# Altium schematic sheet 04 - FT2232_USB_UART_JTAG.SchDoc("04 - FT2232_USB_UART_JTAG")
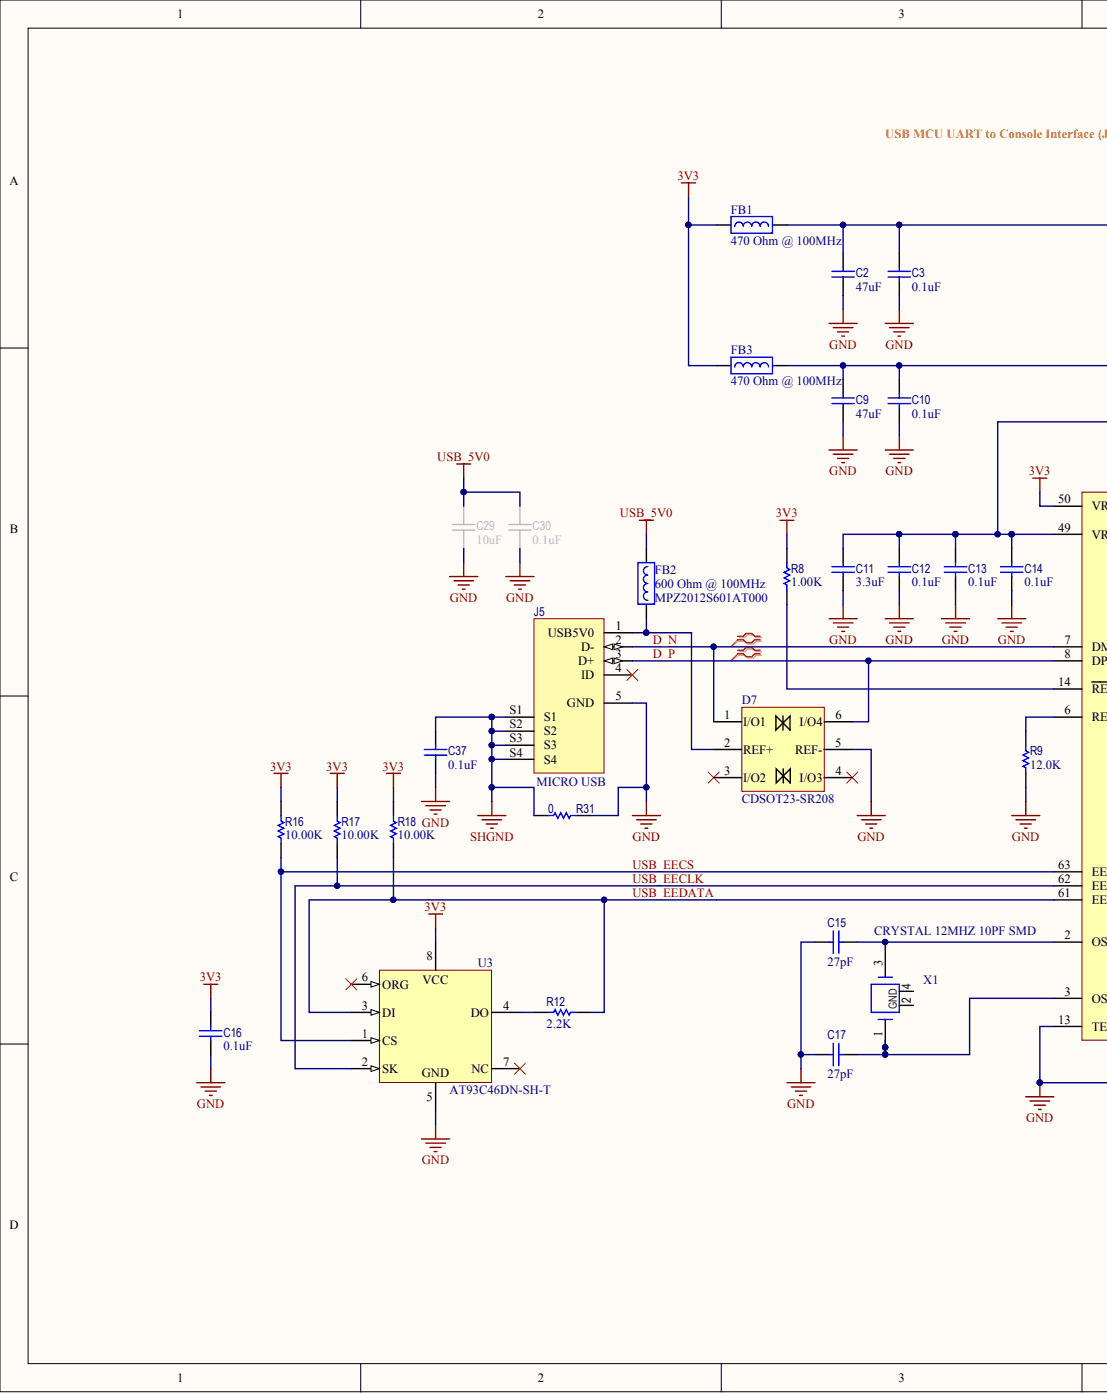
[diagram p0: 04 - FT2232_USB_UART_JTAG.SchDoc, left]
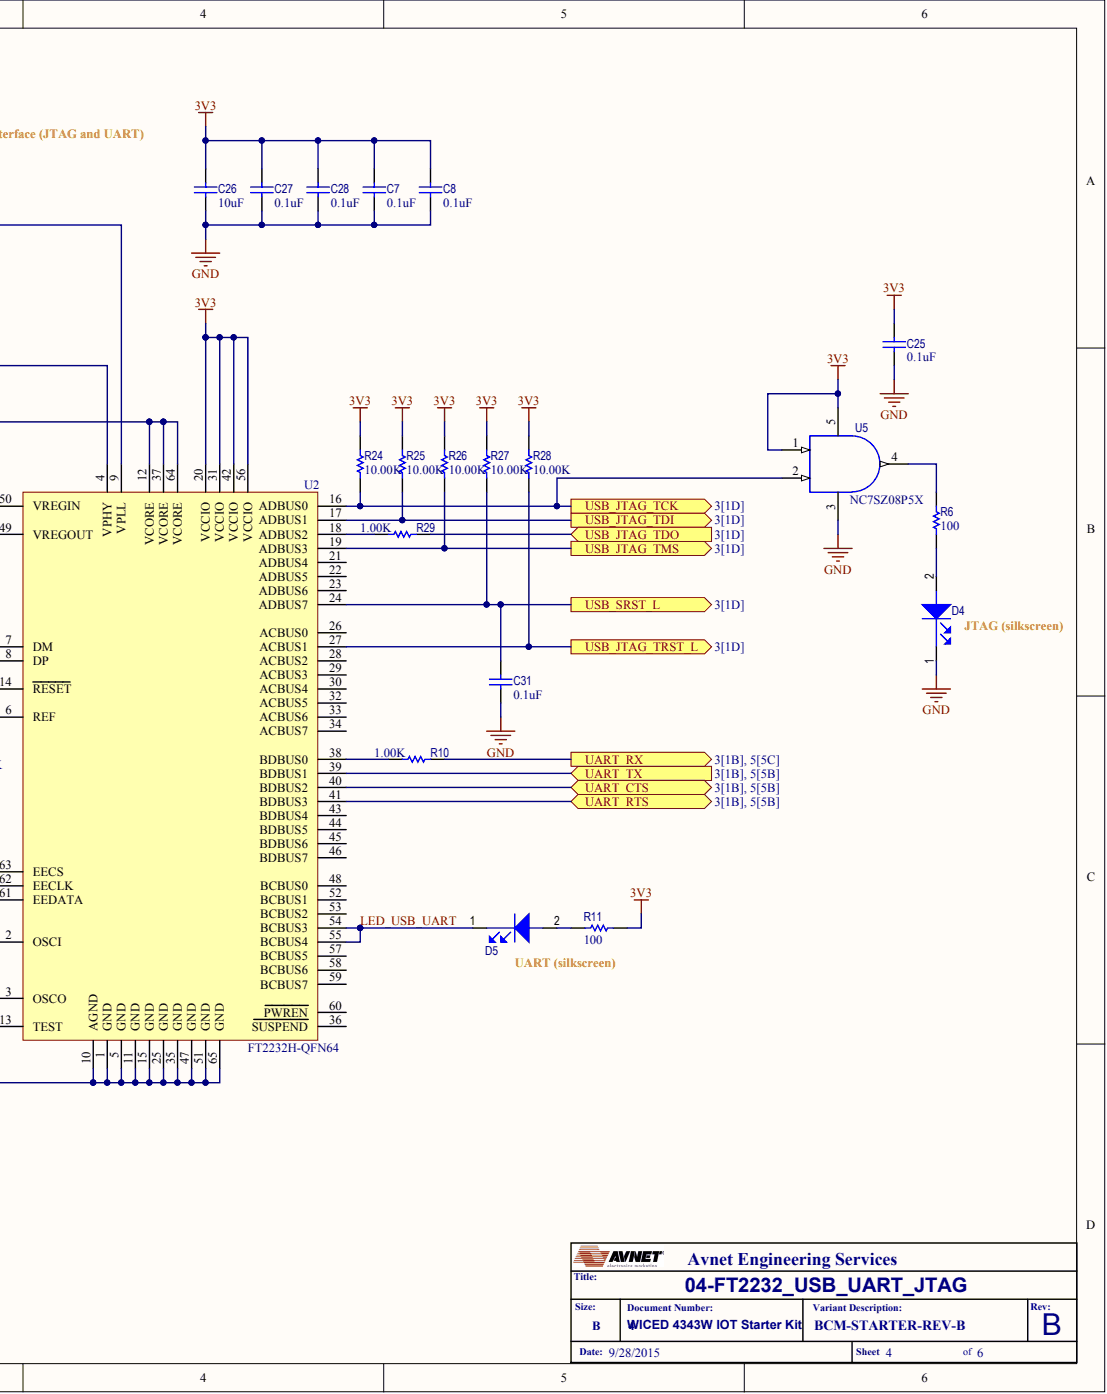
[diagram p1: 04 - FT2232_USB_UART_JTAG.SchDoc, right]

## Parts
C2  47uF  [CAPC0805(2012)155_N]
C3  0.1uF  [CAPC0402(1005)70_N]
C7  0.1uF  [CAPC0402(1005)70_N]
C8  0.1uF  [CAPC0402(1005)70_N]
C9  47uF  [CAPC0805(2012)155_N]
C10  0.1uF  [CAPC0402(1005)70_N]
C11  value 3.3uF  [CAPC0402(1005)70_N]  (hidden comment: CAP CER 3.3UF 10V 10% X5R 0402)
C12  0.1uF  [CAPC0402(1005)70_N]
C13  0.1uF  [CAPC0402(1005)70_N]
C14  0.1uF  [CAPC0402(1005)70_N]
C15  value 27pF  [CAPC0402(1005)70_N]  (hidden comment: CAP CER 27PF 50V 5% NP0 0402)
C16  0.1uF  [CAPC0402(1005)70_N]
C17  value 27pF  [CAPC0402(1005)70_N]  (hidden comment: CAP CER 27PF 50V 5% NP0 0402)
C25  0.1uF  [CAPC0402(1005)70_N]
C26  10uF  [CAPC0402(1005)70_N]
C27  0.1uF  [CAPC0402(1005)70_N]
C28  0.1uF  [CAPC0402(1005)70_N]
C29  10uF  [CAPC0402(1005)70_N]
C30  0.1uF  [CAPC0402(1005)70_N]
C31  0.1uF  [CAPC0402(1005)70_N]
C37  0.1uF  [CAPC0402(1005)70_N]
D4  LED CHIPLED BLUE 470NM 0603 SMD  [D0603 (1608 Metric), BLUE]  (value not drawn)
D5  LED CHIPLED BLUE 470NM 0603 SMD  [D0603 (1608 Metric), BLUE]  (value not drawn)
D7  MFGPN 1: CDSOT23-SR208  [SOT-23-6]  (hidden comment: TVS DIODE 20VWM SMD)
FB1  value 470  [FB-0402(1005 Metric)]  (hidden comment: INDUSTRIAL)
FB2  value 600  MFGPN 1: MPZ2012S601AT000  [FB-0805(2012 Metric)]  (hidden comment: INDUSTRIAL)
FB3  value 470  [FB-0402(1005 Metric)]  (hidden comment: INDUSTRIAL)
J5  Material: MICRO USB  [MOLEX_0475890001]  (hidden comment: CONN RCPT MICRO USB AB R/A SMD)
R6  100  [RESC0402(1005)_N]
R8  1.00K  [RESC0402(1005)_N]
R9  12.0K  [RESC0402(1005)_N]
R10  1.00K  [RESC0402(1005)_N]
R11  100  [RESC0402(1005)_N]
R12  2.2K  [RESC0402(1005)_N]
R16  10.00K  [RESC0402(1005)_N]
R17  10.00K  [RESC0402(1005)_N]
R18  10.00K  [RESC0402(1005)_N]
R24  10.00K  [RESC0402(1005)_N]
R25  10.00K  [RESC0402(1005)_N]
R26  10.00K  [RESC0402(1005)_N]
R27  10.00K  [RESC0402(1005)_N]
R28  10.00K  [RESC0402(1005)_N]
R29  1.00K  [RESC0402(1005)_N]
R31  0  [RESC0402(1005)_N]
U2  MFGPN 1: FT2232H-QFN64  [QFN64_2_N]  (hidden comment: IC USB HS DUAL UART/FIFO 64-QFN)
U3  MFGPN 1: AT93C46DN-SH-T  [8-SOIC]  (hidden comment: IC EEPROM 1KBIT 2MHZ 8SOIC)
U5  MFGPN 1: NC7SZ08P5X  [SOT353 (SC70-5)]  (hidden comment: AND SM LVCMOS Single)
X1  CRYSTAL 12MHZ 10PF SMD  [XTAL_ABM8]

## Ports
UART_CTS, UART_RTS, UART_RX, UART_TX, USB_JTAG_TCK, USB_JTAG_TDI, USB_JTAG_TDO, USB_JTAG_TMS, USB_JTAG_TRST_L, USB_SRST_L

## Nets
3V3: C7.2 C8.2 C16.2 C25.2 C26.2 C27.2 C28.2 FB1.1 FB3.1 R8.2 R11.1 R16.2 R17.2 R18.2 R24.2 R25.2 R26.2 R27.2 R28.2 U2.20(VCCIO) U2.31(VCCIO) U2.42(VCCIO) U2.50(VREGIN) U2.56(VCCIO) U3.8 U5.1 U5.5
GND: C2.1 C3.1 C7.1 C8.1 C9.1 C10.1 C11.1 C12.1 C13.1 C14.1 C15.1 C16.1 C17.1 C25.1 C26.1 C27.1 C28.1 C29.1 C30.1 C31.1 C37.1 D4.1 D7.5(REF-) J5.5(GND) R9.1 R31.2 U2.1(GND) U2.5(GND) U2.10(AGND) U2.11(GND) U2.13(TEST) U2.15(GND) U2.25(GND) U2.35(GND) U2.47(GND) U2.51(GND) U2.65(GND) U3.5 U5.3
SHGND: C37.2 J5.S1 J5.S2 J5.S3 J5.S4 R31.1
USB_5V0: C29.2 C30.2 FB2.2
D_N: D7.1(I/O1) J5.2(D-) U2.7(DM)
D_P: D7.6(I/O4) J5.3(D+) U2.8(DP)
LED_USB_UART: D5.1 U2.54(BCBUS3) U2.55(BCBUS4)
UART_CTS: U2.40(BDBUS2) ; port UART_CTS
UART_RTS: U2.41(BDBUS3) ; port UART_RTS
UART_RX: R10.1 ; port UART_RX
UART_TX: U2.39(BDBUS1) ; port UART_TX
USB_EECLK: R17.1 U2.62(EECLK) U3.2(SK)
USB_EECS: R16.1 U2.63(EECS) U3.1(CS)
USB_EEDATA: R12.2 R18.1 U2.61(EEDATA) U3.3(DI)
USB_JTAG_TCK: R24.1 U2.16(ADBUS0) U5.2 ; port USB_JTAG_TCK
USB_JTAG_TDI: R25.1 U2.17(ADBUS1) ; port USB_JTAG_TDI
USB_JTAG_TDO: R29.1 ; port USB_JTAG_TDO
USB_JTAG_TMS: R26.1 U2.19(ADBUS3) ; port USB_JTAG_TMS
USB_JTAG_TRST_L: R28.1 U2.27(ACBUS1) ; port USB_JTAG_TRST_L
USB_SRST_L: C31.2 R27.1 U2.24(ADBUS7) ; port USB_SRST_L
NetC2_2: C2.2 C3.2 FB1.2 U2.9(VPLL)
NetC9_2: C9.2 C10.2 FB3.2 U2.4(VPHY)
NetC11_2: C11.2 C12.2 C13.2 C14.2 U2.12(VCORE) U2.37(VCORE) U2.49(VREGOUT) U2.64(VCORE)
NetC15_2: C15.2 U2.2(OSCI) X1.3
NetC17_2: C17.2 U2.3(OSCO) X1.1
NetD4_2: D4.2 R6.1
NetD5_2: D5.2 R11.2
NetD7_2: D7.2(REF+) FB2.1 J5.1(USB5V0)
NetR6_2: R6.2 U5.4
NetR8_1: R8.1 U2.14(RESET)
NetR9_2: R9.2 U2.6(REF)
NetR10_2: R10.2 U2.38(BDBUS0)
NetR12_1: R12.1 U3.4(DO)
NetR29_2: R29.2 U2.18(ADBUS2)

Not connected: D7 3(I/O2) 4(I/O3); J5 4(ID); U2 21(ADBUS4) 22(ADBUS5) 23(ADBUS6) 26(ACBUS0) 28(ACBUS2) 29(ACBUS3) 30(ACBUS4) 32(ACBUS5) 33(ACBUS6) 34(ACBUS7) 36(SUSPEND) 43(BDBUS4) 44(BDBUS5) 45(BDBUS6) 46(BDBUS7) 48(BCBUS0) 52(BCBUS1) 53(BCBUS2) 57(BCBUS5) 58(BCBUS6) 59(BCBUS7) 60(PWREN); U3 6(ORG) 7(NC); X1 2 4

## Library data of the parts
C2 C9 = AVPC-0000076,A-0: CAP CER 47UF 10V 20% X5R 0805; Material: CERAMIC; MFG 1: TDK; MFGPN 1: C2012X5R1A476M125AC; Mount Type (SM/TH): SM; Numeric Value (R=Ω, C=uF, L=uH, FB=Ω ): 47; Polarized (Y/N): N; Preferrence Code: A; Temp-Range: -55 to 85C; AES PN: AVPC-0000076; Component Kind: Standard; Component Type: CAP; Dielectric Type: X5R; MFG 1 Datasheet link: http://www.tdk.com/pdf/TDKMLCCCapRange.pdf; Package: 0805 (2012 Metric); Rated Voltage: 10V; RoHS: Y; Tolerance: 20%; Value: 47uF; Library Name: AES Altium Vault
C3 C7 C8 C10 C12-C14 C16 C25 C27 C28 C30 C31 C37 = AVPC-0000034,A-0: CAP CER 0.1UF 25V 10% X7R 0402; Material: CERAMIC; MFG 1: AVX; MFGPN 1: 04023C104KAT2A; Mount Type (SM/TH): SM; Numeric Value (R=Ω, C=uF, L=uH, FB=Ω ): 0.1; Polarized (Y/N): N; Preferrence Code: A; Temp-Range: -55 to 125C; AES PN: AVPC-0000034; Component Kind: Standard; Component Type: CAP; Dielectric Type: X7R; MFG 1 Datasheet link: http://www.avx.com/docs/Catalogs/cx7r.pdf; Package: 0402 (1005 Metric); Rated Voltage: 25V; RoHS: Y; Tolerance: 10%; Value: 0.1uF; Library Name: BD-BCM-STARTER.SchLib
C11 = AVPC-0000109,A-1: Material: CERAMIC; MFG 1: TDK; MFGPN 1: C1005X5R1A335K050BC; Mount Type (SM/TH): SM; Numeric Value (R=Ω, C=uF, L=uH, FB=Ω ): 3.3; Polarized (Y/N): N; Preferrence Code: A; Temp-Range: -55 to 85C; AES PN: AVPC-0000109; Component Kind: Standard; Component Type: CAP; Description: CAP CER 3.3UF 10V 10% X5R 0402; Dielectric Type: X5R; MFG 1 Datasheet link: http://www.tdk.com/pdf/TDKMLCCCapRange.pdf; Package: 0402 (1005 Metric); Rated Voltage: 10V; RoHS: Y; Tolerance: 10%; Library Name: AES Altium Vault
C15 C17 = AVPC-0000165,A-0: Material: CERAMIC; MFG 1: AVX; MFGPN 1: 04025A270JAT2A; Mount Type (SM/TH): SM; Numeric Value (R=Ω, C=uF, L=uH, FB=Ω ): 0.000027; Polarized (Y/N): N; Preferrence Code: A; Temp-Range: -55 to 125C; AES PN: AVPC-0000165; Component Kind: Standard; Component Type: CAP; Description: CAP CER 27PF 50V 5% NP0 0402; Dielectric Type: NP0; MFG 1 Datasheet link: http://www.avx.com/docs/Catalogs/ccog.pdf; Package: 0402 (1005 Metric); Rated Voltage: 50V; RoHS: Y; Tolerance: 5%; Library Name: AES Altium Vault
C26 C29 = AVPC-0000035,A-0: CAP CER 10UF 10V 20% X5R 0402; Material: CERAMIC; MFG 1: SAMSUNG; MFGPN 1: CL05A106MP5NUNC; Mount Type (SM/TH): SM; Numeric Value (R=Ω, C=uF, L=uH, FB=Ω ): 10; Polarized (Y/N): N; Preferrence Code: A; Temp-Range: -55 to 85C; AES PN: AVPC-0000035; Component Kind: Standard; Component Type: CAP; Dielectric Type: X5R; MFG 1 Datasheet link: http://www.samsungsem.com/global/support/library/product-catalog/__icsFiles/afieldfile/2014/11/13/MLCC.pdf; Package: 0402 (1005 Metric); Rated Voltage: 10V; RoHS: Y; Tolerance: 20%; Value: 10uF; Library Name: AES Altium Vault
D4 = AVDL-0000012,A-0: Forward Voltage: 2.85V; Lense Type: Diffused; MFG 1: OSRAM; MFGPN 1: LB Q39G-L2N2-35-1; Millicandela Rating: 29.5mcd; Mount Type (SM/TH): SM; Preferrence Code: A; Temp-Range: -40 to 85C; Wave-PK: 472nm; AES PN: AVDL-0000012; Color: BLUE; Component Kind: Standard; Component Type: LED; Description: LED CHIPLED BLUE 470NM 0603 SMD; MFG 1 Datasheet link: http://media.digikey.com/pdf/Data%20Sheets/Osram%20PDFs/LB_LT%20Q39G.pdf; Package: D0603 (1608 Metric); RoHS: Y; Wavelength: 470nm; Library Name: AES Altium Vault
D5 = AVDL-0000012,A-0: Forward Voltage: 2.85V; Impedance @ Frequency: 473nm; Lense Type: Diffused; MFG 1: OSRAM; MFGPN 1: LB Q39G-L2N2-35-1; Millicandela Rating: 29.5mcd; Mount Type (SM/TH): SM; Preferrence Code: A; Temp-Range: -40 to 85C; Wave-PK: 472nm; AES PN: AVDL-0000012; Color: BLUE; Component Kind: Standard; Component Type: LED; Description: LED CHIPLED BLUE 470NM 0603 SMD; MFG 1 Datasheet link: http://media.digikey.com/pdf/Data%20Sheets/Osram%20PDFs/LB_LT%20Q39G.pdf; Package: D0603 (1608 Metric); RoHS: Y; Wavelength: 470nm; Library Name: AES Altium Vault
D7 = AVDD-0000005,A-0: Forward Voltage: 1.2V @ 50mA; MFG 1: BOURNS; Mount Type (SM/TH): SM; Preferrence Code: A; Rated Current: 700mA (IFRM); Rated Voltage: 20V; Temp-Range: -55 to 150C(TJ); AES PN: AVDD-0000005; Component Kind: Standard; Component Type: DIODE; Description: TVS DIODE 20VWM SMD; Material: TVS; MFG 1 Datasheet link: http://www.bourns.com/data/global/pdfs/CDSOT23-SR208.pdf; Package: SOT-23-6; RoHS: Y; Library Name: AES Altium Vault
FB1 FB3 = AVPI-0000025,A-3: FERRITE BEAD 470 OHM 0.25A 0402; DC Resistance (DCR): 500 mOhm Max; Impedance @ Frequency: 470 Ohm @ 100MHz; MFG 1: TDK; MFGPN 1: MMZ1005Y471CT000; Mount Type (SM/TH): SM; Preferrence Code: A; Rated Current: 0.25; Temp-Range: -55 to 125C; Test Frequency: 100MHz; AES PN: AVPI-0000025; Component Kind: Standard; Component Type: INDUCTOR; Material: FERRITE BEAD; MFG 1 Datasheet link: http://product.tdk.com/emc/beads/en/documents/beads_commercial_signal_mmz_en.pdf; Numeric Value (R=Ω, C=uF, L=uH, FB=Ω ): 470; Package: 0402 (1005 Metric); RoHS: Y; Library Name: AES Altium Vault
FB2 = AVPI-0000071,A-4: FERRITE BEAD 600 OHM 2.0A 0805; DC Resistance (DCR): 100 mOhm Max; Impedance @ Frequency: 600 Ohm @ 100MHz; MFG 1: TDK; Mount Type (SM/TH): SM; Preferrence Code: A; Rated Current: 2; Temp-Range: -55 to 125C; Test Frequency: 100MHz; AES PN: AVPI-0000071; Component Kind: Standard; Component Type: INDUCTOR; Material: FERRITE BEAD; MFG 1 Datasheet link: http://product.tdk.com/en/catalog/datasheets/beads_commercial_power_mpz_en.pdf; Numeric Value (R=Ω, C=uF, L=uH, FB=Ω ): 600; Package: 0805 (2012 Metric); RoHS: Y; Library Name: AES Altium Vault
J5 = AVEC-0000011,A-3: Gender (M/F): Receptacle; Mating Plug: USB Micro; MFG 1: MOLEX; MFGPN 1: 475890001; Mount Type (SM/TH): SM; Preferrence Code: A; Rated Current: 1.8A per contact; Rated Voltage: 30VAC (RMS); Shielding: Shielded; Temp-Range: -30 to 85C; AES PN: AVEC-0000011; Applications: USB - Micro AB; Color: -; Component Kind: Standard; Component Type: CONNECTOR; Contact Type: Gold, 0.11um (over 0.75 um Pd/Ni); Description: CONN RCPT MICRO USB AB R/A SMD; Features: Board Guide, Shielded; MFG 1 Datasheet link: http://www.molex.com/pdm_docs/sd/475890001_sd.pdf; Orientation: Right Angle; Package: MOLEX_0475890001; RoHS: Y; Library Name: AES Altium Vault
R6 R11 = AVPR-0000783,A-2: RES 100 OHM 0402 1% 1/16W; MFG 1: TBD; Mount Type (SM/TH): SM; Preferrence Code: A; Rated Power: 0.063W; Temp-Range: -55 to 125C; AES PN: AVPR-0000783; Component Kind: Standard; Component Type: RESISTOR; Material: Thick Film; MFG 2: TBD; MFG 3: TBD; MFGPN 1: TBD; MFGPN 2: TBD; MFGPN 3: TBD; Numeric Value (R=Ω, C=uF, L=uH, FB=Ω ): 100; Package: 0402 (1005 Metric); RoHS: Y; Tolerance: 1%; Value: 100; Library Name: AES Altium Vault
R8 R10 R29 = AVPR-0000880,A-2: RES 1.00K OHM 0402 1% 1/16W; MFG 1: TBD; Mount Type (SM/TH): SM; Numeric Value (R=Ω, C=uF, L=uH, FB=Ω ): 1000; Preferrence Code: A; Rated Power: 0.063W; Temp-Range: -55 to 125C; AES PN: AVPR-0000880; Component Kind: Standard; Component Type: RESISTOR; Material: Thick Film; MFG 2: TBD; MFG 3: TBD; MFGPN 1: TBD; MFGPN 2: TBD; MFGPN 3: TBD; Package: 0402 (1005 Metric); RoHS: Y; Tolerance: 1%; Value: 1.00K; Library Name: AES Altium Vault
R9 = AVPR-0005352,A-0: RES 12.0K OHM 0402 1% 1/16W; MFG 1: TBD; Mount Type (SM/TH): SM; Numeric Value (R=Ω, C=uF, L=uH, FB=Ω ): 12000; Preferrence Code: A; Rated Power: 0.063W; Temp-Range: -55 to 125C; AES PN: AVPR-0005352; Component Kind: Standard; Component Type: RESISTOR; Material: Thick Film; MFG 2: TBD; MFG 3: TBD; MFGPN 1: TBD; MFGPN 2: TBD; MFGPN 3: TBD; Package: 0402 (1005 Metric); RoHS: Y; Tolerance: 1%; Value: 12.0K; Library Name: AES Altium Vault
R12 = AVPR-0005367,A-0: RES 2.2K OHM 0402 1% 1/16W; MFG 1: TBD; Mount Type (SM/TH): SM; Numeric Value (R=Ω, C=uF, L=uH, FB=Ω ): 2200; Preferrence Code: A; Rated Power: 0.063W; Temp-Range: -55 to 125C; AES PN: AVPR-0005367; Component Kind: Standard; Component Type: RESISTOR; Material: Thick Film; MFG 2: TBD; MFG 3: TBD; MFGPN 1: TBD; MFGPN 2: TBD; MFGPN 3: TBD; Package: 0402 (1005 Metric); RoHS: Y; Tolerance: 1%; Value: 2.2K; Library Name: AES Altium Vault
R16-R18 R24-R28 = AVPR-0000977,A-2: RES 10.0K OHM 0402 1% 1/16W; MFG 1: TBD; Mount Type (SM/TH): SM; Numeric Value (R=Ω, C=uF, L=uH, FB=Ω ): 10000; Preferrence Code: A; Rated Power: 0.063W; Temp-Range: -55 to 125C; AES PN: AVPR-0000977; Component Kind: Standard; Component Type: RESISTOR; Material: Thick Film; MFG 2: TBD; MFG 3: TBD; MFGPN 1: TBD; MFGPN 2: TBD; MFGPN 3: TBD; Package: 0402 (1005 Metric); RoHS: Y; Tolerance: 1%; Value: 10.00K; Library Name: BD-BCM-STARTER.SchLib
R31 = AVPR-0000585,A-2: RES 0.0 OHM JUMP 0402 1/16W; MFG 1: TBD; Mount Type (SM/TH): SM; Preferrence Code: A; Rated Power: 0.063W; Temp-Range: -55 to 125C; Tolerance: 1%; AES PN: AVPR-0000585; Component Kind: Standard; Component Type: RESISTOR; Material: Thick Film; MFG 2: TBD; MFG 3: TBD; MFGPN 1: TBD; MFGPN 2: TBD; MFGPN 3: TBD; Numeric Value (R=Ω, C=uF, L=uH, FB=Ω ): 0; Package: 0402 (1005 Metric); RoHS: Y; Value: 0; Library Name: BD-BCM-STARTER.SchLib
U2 = AVID-0000024,A-0: Data Rate: RS232/RS422/RS485 UART Up to 12Mbaud; Frequency: 12MHz – 480MHz; Interface: Bridge, USB to UART; MFG 1: FTDI; Mount Type (SM/TH): SM; Number of Channels: 2; Preferrence Code: A; Supply Voltage: 3.3 - 3.6V; Temp-Range: -40 to 85C; AES PN: AVID-0000024; Component Kind: Standard; Component Type: IC; Description: IC USB HS DUAL UART/FIFO 64-QFN; Logic High: -; Logic Low: -; Logic Type: -; Material: QFN; MFG 1 Datasheet link: http://www.ftdichip.com/Support/Documents/DataSheets/ICs/DS_FT2232H.pdf; Number of Inputs: -; Number of Outputs: -; Package: 64-QFN; Propagation Delay: -; RoHS: Y; Standards: -; Library Name: AES Altium Vault
U3 = AVIM-0000012,A-0: Interface: Microwire, 3-Wire Serial; Memory Size: 1K (128 x 8 or 64 x 16); MFG 1: ATMEL; Mount Type (SM/TH): SM; Preferrence Code: A; Speed: 250kHz, 1MHz, 2MHz; Supply Voltage: 1.8 to 5.5V; Temp-Range: -40 to 85C; AES PN: AVIM-0000012; Component Kind: Standard; Component Type: IC; Description: IC EEPROM 1KBIT 2MHZ 8SOIC; Material: GULL; Memory Type: EEPROM; MFG 1 Datasheet link: http://www.atmel.com/images/atmel-5193-seeprom-at93c46d-datasheet.pdf; Package: 8-SOIC; RoHS: Y; Library Name: AES Altium Vault
U5 = AVID-0000025,A-0: Logic High: 0.7-0.75Vcc; Logic Low: 0.25-0.3Vcc; Logic Type: AND Gate; MFG 1: FAIRCHILD; Number of Channels: 1; Number of Inputs: 2; Preferrence Code: A; Propagation Delay: 4.5ns @ 5V, 50pF; Supply Voltage: 1.65 to 5.5V; Temp-Range: -40 to 85C; AES PN: AVID-0000025; Component Kind: Standard; Component Type: IC; Data Rate: -; Description: AND SM LVCMOS Single; Frequency: -; Interface: -; Material: GULL; MFG 1 Datasheet link: http://www.fairchildsemi.com/datasheets/NC/NC7SZ08.pdf; Mount Type (SM/TH): SM; Package: SOT-353 (SC-70-5); RoHS: Y; Standards: -; Library Name: AES Altium Vault
X1 = AVPT-0000001,A-4: Frequency Stability: ±30ppm; MFG 1: ABRACON; MFGPN 1: ABM8G-12.000MHZ-B4Y-T; Mount Type (SM/TH): SM; Preferrence Code: A; Temp-Range: -20 to 70C; AES PN: AVPT-0000001; Component Kind: Standard; Component Type: IC; Description: CRYSTAL 12MHZ 10PF SMD; Frequency: 12MHz; Material: DFN; MFG 1 Datasheet link: http://www.abracon.com/Resonators/ABM8G.pdf; Output: -; Package: 4-SMD, No Lead (DFN, LCC); RoHS: Y; Supply Voltage: -; Library Name: AES Altium Vault
